# Altium schematic sheet esp32s2_core.SchDoc
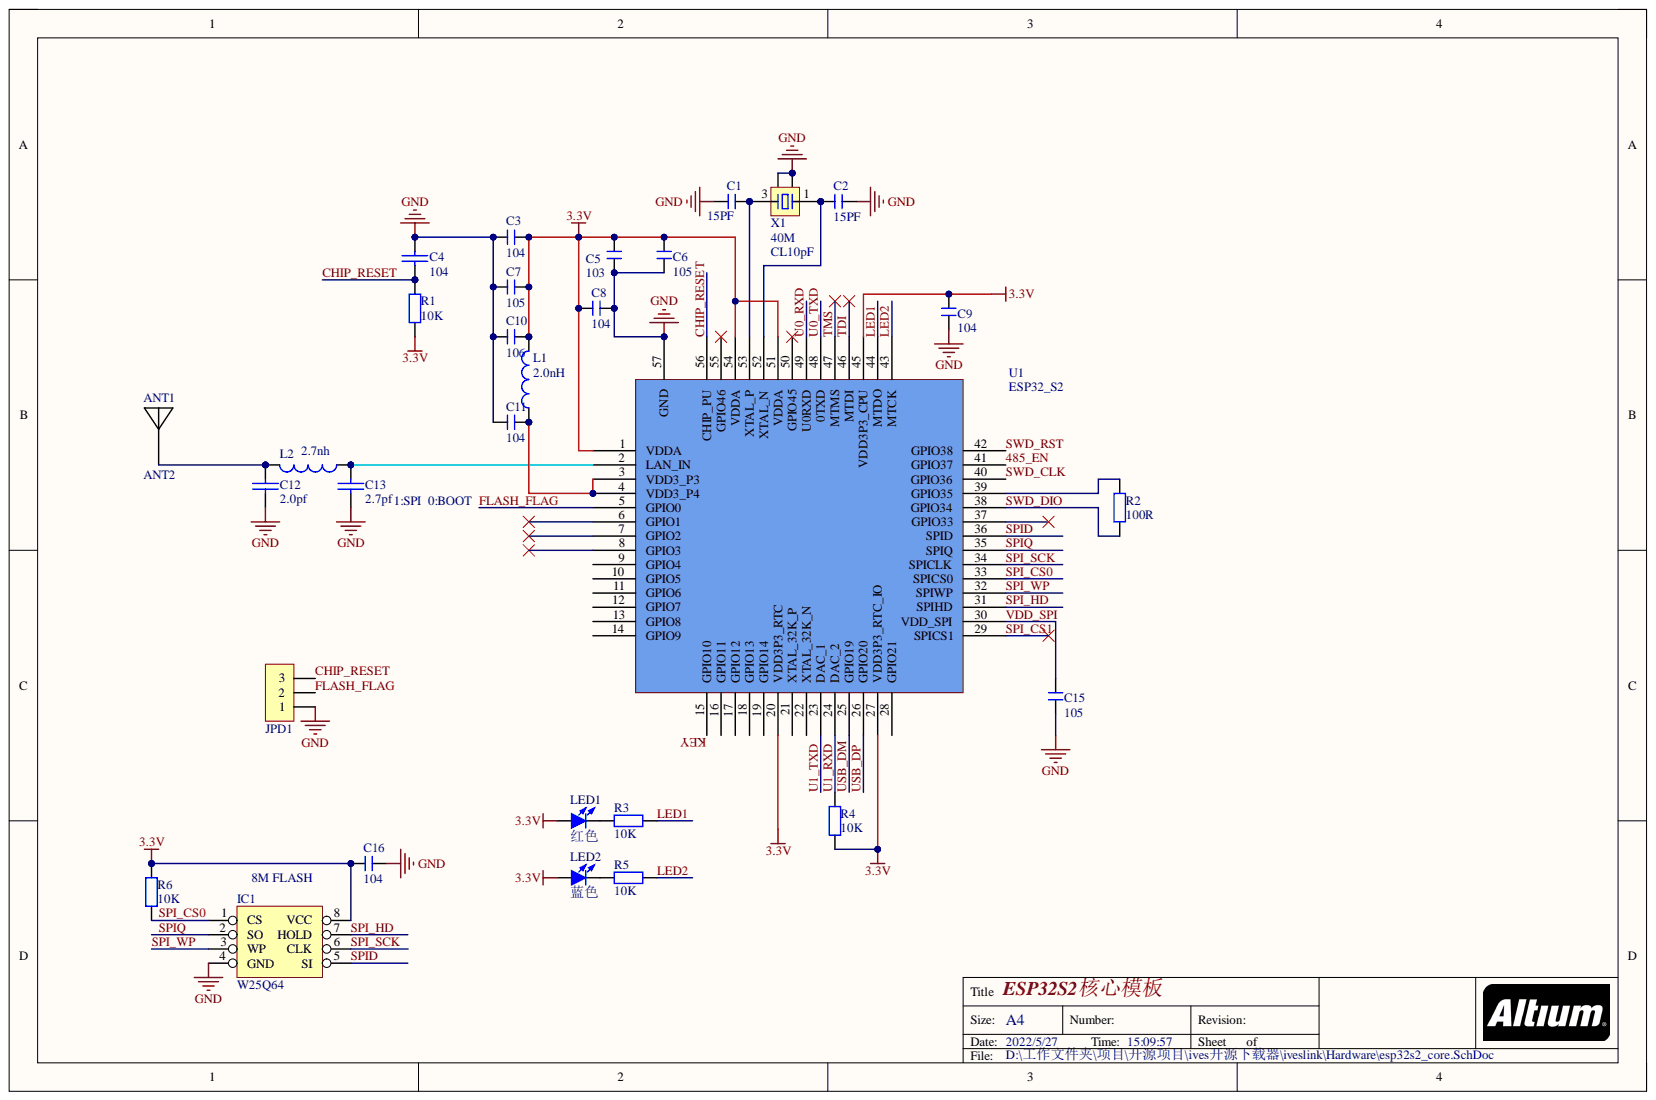
[diagram p0: esp32s2_core.SchDoc]

## Parts
ANT1  ANT2  [ANT-PCB-2.4G]
C1  15PF  [C 0402_L]
C2  15PF  [C 0402_L]
C3  104  [C 0402_L]
C4  104  [C 0402_L]
C5  103  [C 0402_L]
C6  105  [C 0402_L]
C7  105  [C 0402_L]
C8  104  [C 0402_L]
C9  104  [C 0402_L]
C10  106  [C 0402_L]
C11  104  [C 0402_L]
C12  2.0pf  [C 0402_L]
C13  2.7pf  [C 0402_L]
C15  105  [C 0402_L]
C16  104  [C 0402_L]
IC1  W25Q64  [SOP8W_L]
JPD1  HDR-1X3  [HDR2.0-LI-3P]  (value not drawn)
L1  2.0nH  [L 0402_l]
L2  2.7nh  [L 0402_L]
LED1  红色  [LED 0603R]
LED2  蓝色  [LED 0603B]
R1  10K  [R 0402_L]
R2  100R  [R 0402_L]
R3  10K  [R 0402_L]
R4  10K  [R 0402_L]
R5  10K  [R 0402_L]
R6  10K  [R 0402_L]
U1  ESP32_S2  [ESP32-QFN56]
X1  40M  [OSC 3225-4P]

## Nets
3.3V: C3.1 C5.2 C6.2 C7.1 C8.2 C9.2 C10.1 C16.2 IC1.8 L1.2 LED1.1 LED2.1 R1.1 R4.1 R6.2 U1.1 U1.20 U1.27 U1.45 U1.51 U1.54
GND: C1.2 C2.2 C3.2 C4.1 C5.1 C6.1 C7.2 C8.1 C9.1 C10.2 C11.2 C12.2 C13.2 C15.2 C16.1 IC1.4 JPD1.1 U1.57 X1.2 X1.4
485_EN: U1.41
CHIP_RESET: C4.2 JPD1.3 R1.2 U1.56
FLASH_FLAG: JPD1.2 U1.5
KEY: U1.15
LED1: R3.1 U1.44
LED2: R5.1 U1.43
SPI_CS0: IC1.1 R6.1 U1.33
SPI_CS1: U1.29
SPI_HD: IC1.7 U1.31
SPI_SCK: IC1.6 U1.34
SPI_WP: IC1.3 U1.32
SPID: IC1.5 U1.36
SPIQ: IC1.2 U1.35
SWD_CLK: U1.40
SWD_DIO: R2.1 U1.38
SWD_RST: U1.42
TDI: U1.46
TMS: U1.47
U0_RXD: U1.49
U0_TXD: U1.48
U1_RXD: R4.2 U1.24
U1_TXD: U1.23
USB_DM: U1.25
USB_DP: U1.26
VDD_SPI: C15.1 U1.30
NetANT1_1: ANT1.1 C12.1 L2.2
NetC1_1: C1.1 U1.53 X1.3
NetC2_1: C2.1 U1.52 X1.1
NetC11_1: C11.1 L1.1 U1.3 U1.4
NetC13_1: C13.1 L2.1 U1.2
NetLED1_2: LED1.2 R3.2
NetLED2_2: LED2.2 R5.2
NetR2_2: R2.2 U1.39

Not connected: U1 6 7 8 9 10 11 12 13 14 16 17 18 19 21 22 28 37 50 55

## Library data of the parts
ANT1 = ANT1: PCB板载天线; 版权归属: 源创客; BOM名称: PCB板载天线; Component Kind: Standard; Library Name: 14.1 - 天线.SchLib; 学习资源: www.ooocke.com
C1-C3 C5-C11 C15 C16 = C: 无极性贴片电容; 材质: X7R; 版权归属: 源创客; BOM名称: 无极性贴片电容; Component Kind: Standard; Library Name: 3.1   - 电阻-电容-电感.SchLib; 学习资源: www.ooocke.com
C4 C12 C13 = CAP: Capacitor; Component Kind: Standard
IC1 = W25X16: Component Kind: Standard; Library Name: YT1_SCH_99SE.SCHLIB
JPD1 = Header 3: 3P接插件; 版权归属: 源创客; BOM名称: 3P接插件; Component Kind: Standard; Library Name: 4.6   - 接插件-脚距2.54.SchLib; 学习资源: www.ooocke.com
L1 L2 = L: 小功率贴片电感; 版权归属: 源创客; BOM名称: 小功率贴片电感; Component Kind: Standard; Library Name: 3.1   - 电阻-电容-电感.SchLib; 学习资源: www.ooocke.com
LED1 LED2 = LED-SMD: 贴片LED; 版权归属: 源创客; BOM名称: 贴片LED; Component Kind: Standard; Library Name: 9.1   - LED.SchLib; 学习资源: www.ooocke.com
R1-R6 = Res2: Resistor; Component Kind: Standard; Library Name: Miscellaneous Devices.IntLib
X1 = XTAL-4P: 4脚无源晶振; 版权归属: 源创客; 负载电容: CL10pF; BOM名称: 4脚无源晶振; Component Kind: Standard; Library Name: 10.1 - 保险丝-晶振.SchLib; 学习资源: www.ooocke.com
U1 = ESP32_S2: Component Kind: Standard; Library Name: ESP32S2原理图库.SchLib
